# Altium schematic sheet PowerSchem.SchDoc(PowerSchem) (continued)
## Library data of the parts (continued)
R56 = RES: 100mW Thick Film Resistors 75V ±100ppm/℃ ±1% 4.99kΩ 0603 Chip Resistor - Surface Mount ROHS; Manufacturer: Yageo Group; Manufacturer Part Number: RC0603FR-074K99L; Temperature Coefficient: 100ppm/°C; Voltage Rating (DC): 50V; Case Code (Imperial): 0603; Case/Package: 0603; Category: Chip SMD Resistors; China RoHS: Non-Compliant; Component Kind: Standard; ComponentLink1Description: https://datasheet.octopart.com:443/RC0603FR-074K99L-Yageo-datasheet-175887523.pdf?src-supplier=IHS; ComponentLink1URL: https://datasheet.octopart.com:443/RC0603FR-074K99L-Yageo-datasheet-175887523.pdf?src-supplier=IHS; ComponentLink2Description: https://datasheet.octopart.com:443/RC0603FR-074K99L-Yageo-datasheet-8328172.pdf?src-supplier=Newark; ComponentLink2URL: https://datasheet.octopart.com:443/RC0603FR-074K99L-Yageo-datasheet-8328172.pdf?src-supplier=Newark; ComponentLink3Description: https://datasheet.octopart.com:443/RC0603FR-074K99L-Yageo-datasheet-34211833.pdf?src-supplier=Newark; ComponentLink3URL: https://datasheet.octopart.com:443/RC0603FR-074K99L-Yageo-datasheet-34211833.pdf?src-supplier=Newark; ComponentLink4Description: https://datasheet.octopart.com:443/RC0603FR-074K99L-Yageo-datasheet-103092701.pdf?src-supplier=Upverter; ComponentLink4URL: https://datasheet.octopart.com:443/RC0603FR-074K99L-Yageo-datasheet-103092701.pdf?src-supplier=Upverter; ComponentLink5Description: https://datasheet.octopart.com:443/RC0603FR-074K99L-Yageo-datasheet-79472498.pdf?src-supplier=Upverter; ComponentLink5URL: https://datasheet.octopart.com:443/RC0603FR-074K99L-Yageo-datasheet-79472498.pdf?src-supplier=Upverter; ComponentLink6Description: https://datasheet.octopart.com:443/RC0603FR-074K99L-Yageo-datasheet-62067350.pdf?src-supplier=Farnell; ComponentLink6URL: https://datasheet.octopart.com:443/RC0603FR-074K99L-Yageo-datasheet-62067350.pdf?src-supplier=Farnell; ComponentLink7Description: https://datasheet.octopart.com:443/RC0603FR-074K99L-Yageo-Group-datasheet-176638170.pdf?src-supplier=Future+Electronics; ComponentLink7URL: https://datasheet.octopart.com:443/RC0603FR-074K99L-Yageo-Group-datasheet-176638170.pdf?src-supplier=Future+Electronics; ComponentLink8Description: https://datasheet.octopart.com:443/RC0603FR-074K99L-Yageo-datasheet-164759695.pdf?src-supplier=Future+Electronics; ComponentLink8URL: https://datasheet.octopart.com:443/RC0603FR-074K99L-Yageo-datasheet-164759695.pdf?src-supplier=Future+Electronics; ComponentLink9Description: https://datasheet.octopart.com:443/RC0603FR-074K99L-Yageo-datasheet-137700087.pdf?src-supplier=Future+Electronics; ComponentLink9URL: https://datasheet.octopart.com:443/RC0603FR-074K99L-Yageo-datasheet-137700087.pdf?src-supplier=Future+Electronics; ComponentLink10Description: https://datasheet.octopart.com:443/RC0603FR-074K99L-Yageo-datasheet-127823281.pdf?src-supplier=Future+Electronics; ComponentLink10URL: https://datasheet.octopart.com:443/RC0603FR-074K99L-Yageo-datasheet-127823281.pdf?src-supplier=Future+Electronics; ComponentLink11Description: https://datasheet.octopart.com:443/RC0603FR074K99L-Yageo-datasheet-27584510.pdf?src-supplier=Future+Electronics; ComponentLink11URL: https://datasheet.octopart.com:443/RC0603FR074K99L-Yageo-datasheet-27584510.pdf?src-supplier=Future+Electronics; ComponentLink12Description: https://datasheet.octopart.com:443/RC0603FR-074K99L-Yageo-datasheet-15599030.pdf?src-supplier=Future+Electronics; ComponentLink12URL: https://datasheet.octopart.com:443/RC0603FR-074K99L-Yageo-datasheet-15599030.pdf?src-supplier=Future+Electronics; ComponentLink13Description: https://datasheet.octopart.com:443/RC0603FR-074K99L-Yageo-datasheet-12596169.pdf?src-supplier=Future+Electronics; ComponentLink13URL: https://datasheet.octopart.com:443/RC0603FR-074K99L-Yageo-datasheet-12596169.pdf?src-supplier=Future+Electronics; ComponentLink14Description: https://datasheet.octopart.com:443/RC0603FR-074K99L-Yageo-datasheet-503470.pdf?src-supplier=Future+Electronics; ComponentLink14URL: https://datasheet.octopart.com:443/RC0603FR-074K99L-Yageo-datasheet-503470.pdf?src-supplier=Future+Electronics; ComponentLink15Description: https://datasheet.octopart.com/RC0603FR-074K99L-Yageo-datasheet-73766026.pdf; ComponentLink15URL: https://datasheet.octopart.com/RC0603FR-074K99L-Yageo-datasheet-73766026.pdf; ComponentLink16Description: https://datasheet.octopart.com:443/RC0603FR-074K99L-Yageo-datasheet-118562.pdf?src-supplier=DigiKey; ComponentLink16URL: https://datasheet.octopart.com:443/RC0603FR-074K99L-Yageo-datasheet-118562.pdf?src-supplier=DigiKey; ComponentLink17Description: http://sigma.octopart.com:80/conflict_mineral_statement/Yageo-company-3.pdf?src-supplier=Compliance+Statements; ComponentLink17URL: http://sigma.octopart.com:80/conflict_mineral_statement/Yageo-company-3.pdf?src-supplier=Compliance+Statements; ComponentLink18Description: http://sigma.octopart.com:80/rohs_statement/Yageo-company-2.pdf?src-supplier=Compliance+Statements; ComponentLink18URL: http://sigma.octopart.com:80/rohs_statement/Yageo-company-2.pdf?src-supplier=Compliance+Statements; Composition: Thick Film; Contact Plating: Tin; Country of Origin: Mainland China, Taiwan; Depth: 800µm; Export Control Classification Number (ECCN) Code: EAR99; Features: Moisture Resistant; Harmonized Tariff Schedule (HTS) Code: 8533.21.00.30; Height: 450µm; Introduction Date: 2004-09-22; Lead Free: Lead Free; Length: 1.6mm; Library Name: Standard.SchLib; Lifecycle Status: Production; Max Operating Temperature: 155°C; Max Power Dissipation: 100mW; Military Standard: Not; Min Operating Temperature: -55°C; Mounting Technology: Surface Mount; Number of Terminals: 2; Number of Terminations: 2; Packaging: Tape & Reel; Pins: 2; Power Rating: 100mW; Pricing: 5000=0.00137, 10000=0.00137, 20000=0.00136, 40000=0.00135, 80000=0.00134 (USD); Radiation Hardening: No; REACH SVHC: Yes; RoHS: Compliant; Schedule B: 8533210045, 8533210045|8533210045|8533210045|8533210045|8533210045|8533210045|8533210045|8533210045|8533210045|8533210045|8533210045|8533210045|8533210045|8533210045|8533210045|8533210045|8533210045|8533210045|8533210045|8533210045|8533210045|8533210045|8533210045|85; Stock: 665000; Supplier 1: Avnet; Supplier Part Number 1: RC0603FR-074K99L; Termination: SMD/SMT; Tolerance: 1%; Value: 4k99; Voltage Rating: 75V; Weight: 2.012816mg; Width: 800µm; Working Voltage: 75V
R57 = RES: Res Thick Film 0603 14.7K Ohm 1% 0.1W(1/10W) ±100ppm/C Pad SMD T/R Automotive AEC-Q200; Manufacturer: Stackpole Electronics; Manufacturer Part Number: RMCF0603FT14K7; Max Power Dissipation: 100mW; Temperature Coefficient: 100ppm/°C; Voltage Rating: 75V; Case Code (Imperial): 0603; Case Code (Metric): 1608; Case/Package: 0603; Category: Chip SMD Resistors; China RoHS: Non-Compliant; Component Kind: Standard; ComponentLink1Description: https://datasheet.octopart.com:443/RMCF0603FT14K7-Stackpole-Electronics-datasheet-182148065.pdf?src-supplier=IHS; ComponentLink1URL: https://datasheet.octopart.com:443/RMCF0603FT14K7-Stackpole-Electronics-datasheet-182148065.pdf?src-supplier=IHS; ComponentLink2Description: https://datasheet.octopart.com:443/RMCF0603FT14K7-Stackpole-Electronics-datasheet-28504820.pdf?src-supplier=Upverter; ComponentLink2URL: https://datasheet.octopart.com:443/RMCF0603FT14K7-Stackpole-Electronics-datasheet-28504820.pdf?src-supplier=Upverter; ComponentLink3Description: https://datasheet.octopart.com:443/RMCF0603FT14K7-Stackpole-Electronics-datasheet-141193804.pdf?src-supplier=Master+Electronics; ComponentLink3URL: https://datasheet.octopart.com:443/RMCF0603FT14K7-Stackpole-Electronics-datasheet-141193804.pdf?src-supplier=Master+Electronics; ComponentLink4Description: https://datasheet.octopart.com:443/RMCF0603FT14K7-Stackpole-Electronics-datasheet-189104163.pdf?src-supplier=Master+Electronics; ComponentLink4URL: https://datasheet.octopart.com:443/RMCF0603FT14K7-Stackpole-Electronics-datasheet-189104163.pdf?src-supplier=Master+Electronics; ComponentLink5Description: https://datasheet.octopart.com:443/RMCF0603FT14K7-Stackpole-Electronics-datasheet-116606903.pdf?src-supplier=Master+Electronics; ComponentLink5URL: https://datasheet.octopart.com:443/RMCF0603FT14K7-Stackpole-Electronics-datasheet-116606903.pdf?src-supplier=Master+Electronics; ComponentLink6Description: https://datasheet.octopart.com:443/RMCF0603FT14K7-Stackpole-Electronics-datasheet-62091608.pdf?src-supplier=Stackpole+Electronics; ComponentLink6URL: https://datasheet.octopart.com:443/RMCF0603FT14K7-Stackpole-Electronics-datasheet-62091608.pdf?src-supplier=Stackpole+Electronics; ComponentLink7Description: https://datasheet.octopart.com:443/RMCF0603FT14K7-Stackpole-Electronics-datasheet-12534581.pdf?src-supplier=Stackpole+Electronics; ComponentLink7URL: https://datasheet.octopart.com:443/RMCF0603FT14K7-Stackpole-Electronics-datasheet-12534581.pdf?src-supplier=Stackpole+Electronics; ComponentLink8Description: https://datasheet.octopart.com:443/RMCF0603FT14K7-Stackpole-Electronics-datasheet-10788263.pdf?src-supplier=Stackpole+Electronics; ComponentLink8URL: https://datasheet.octopart.com:443/RMCF0603FT14K7-Stackpole-Electronics-datasheet-10788263.pdf?src-supplier=Stackpole+Electronics; ComponentLink9Description: http://sigma.octopart.com:80/15940719/materials_sheet/Stackpole-Electronics-RMCF0603FT14K7.pdf?src-supplier=Compliance+Statements; ComponentLink9URL: http://sigma.octopart.com:80/15940719/materials_sheet/Stackpole-Electronics-RMCF0603FT14K7.pdf?src-supplier=Compliance+Statements; ComponentLink10Description: http://sigma.octopart.com:80/141158212/rohs_statement/Stackpole-Electronics-RMCF0603FT14K7.pdf?src-supplier=Compliance+Statements; ComponentLink10URL: http://sigma.octopart.com:80/141158212/rohs_statement/Stackpole-Electronics-RMCF0603FT14K7.pdf?src-supplier=Compliance+Statements; ComponentLink11Description: http://sigma.octopart.com:80/141158211/reach_statement/Stackpole-Electronics-RMCF0603FT14K7.pdf?src-supplier=Compliance+Statements; ComponentLink11URL: http://sigma.octopart.com:80/141158211/reach_statement/Stackpole-Electronics-RMCF0603FT14K7.pdf?src-supplier=Compliance+Statements; Composition: Thick Film; Contact Plating: Tin; Country of Origin: Japan, Mainland China, Taiwan; Export Control Classification Number (ECCN) Code: EAR99; Features: Automotive AEC-Q200; Harmonized Tariff Schedule (HTS) Code: 8533.21.00.30; Height: 450µm; Introduction Date: 2011-01-07; Lead Free: Lead Free; Length: 1.55mm; Library Name: Standard.SchLib; Lifecycle Status: Production; Max Operating Temperature: 155°C; Min Operating Temperature: -55°C; Mounting Technology: Surface Mount; Number of Terminals: 2; Number of Terminations: 2; Packaging: Cut Tape (CT); Power Rating: 100mW; Pricing: 5000=0.0019, 10000=0.00188, 20000=0.00185, 40000=0.00182, 80000=0.00179 (USD); Radiation Hardening: No; Ratings: AEC-Q200; REACH SVHC: Yes; RoHS: Compliant; Schedule B: 8533210045, 8533210045|8533210045|8533210045|8533210045|8533210045; Stock: 170000; Supplier 1: Avnet; Supplier Part Number 1: RMCF0603FT14K7; Tolerance: 1%; Value: 14k7; Width: 800µm; Working Voltage: 75V
R59 = RES: 100mW Thick Film Resistors 75V ±100ppm/℃ ±1% 113kΩ 0603 Chip Resistor - Surface Mount ROHS; Manufacturer: Yageo Group; Manufacturer Part Number: RC0603FR-07113KL; Temperature Coefficient: 100ppm/°C; Voltage Rating (DC): 50V; Case Code (Imperial): 0603; Case/Package: 0603; Category: Chip SMD Resistors; China RoHS: Non-Compliant; Component Kind: Standard; ComponentLink1Description: https://datasheet.octopart.com:443/CPF0402B200KE1-TE-Connectivity-datasheet-168801553.pdf?src-supplier=Farnell; ComponentLink1URL: https://datasheet.octopart.com:443/CPF0402B200KE1-TE-Connectivity-datasheet-168801553.pdf?src-supplier=Farnell; ComponentLink2Description: https://datasheet.octopart.com:443/CPF0402B200KE1-TE-Connectivity-datasheet-66077623.pdf?src-supplier=Farnell; ComponentLink2URL: https://datasheet.octopart.com:443/CPF0402B200KE1-TE-Connectivity-datasheet-66077623.pdf?src-supplier=Farnell; ComponentLink3Description: https://datasheet.octopart.com:443/CPF0402B200KE1-TE-Connectivity-datasheet-16487054.pdf?src-supplier=Farnell; ComponentLink3URL: https://datasheet.octopart.com:443/CPF0402B200KE1-TE-Connectivity-datasheet-16487054.pdf?src-supplier=Farnell; ComponentLink4Description: https://datasheet.octopart.com:443/CPF0402B200KE1-TE-Connectivity-datasheet-136771900.pdf?src-supplier=Electro+Sonic; ComponentLink4URL: https://datasheet.octopart.com:443/CPF0402B200KE1-TE-Connectivity-datasheet-136771900.pdf?src-supplier=Electro+Sonic; ComponentLink5Description: https://datasheet.octopart.com:443/CPF0402B200KE1-Tyco-Electronics-datasheet-11120060.pdf?src-supplier=Electro+Sonic; ComponentLink5URL: https://datasheet.octopart.com:443/CPF0402B200KE1-Tyco-Electronics-datasheet-11120060.pdf?src-supplier=Electro+Sonic; ComponentLink6Description: https://datasheet.octopart.com:443/CPF0402B200KE1-TE-Connectivity-datasheet-78339038.pdf?src-supplier=Master+Electronics; ComponentLink6URL: https://datasheet.octopart.com:443/CPF0402B200KE1-TE-Connectivity-datasheet-78339038.pdf?src-supplier=Master+Electronics; ComponentLink7Description: https://datasheet.octopart.com:443/CPF0402B200KE1-TE-Connectivity-datasheet-62056503.pdf?src-supplier=Master+Electronics; ComponentLink7URL: https://datasheet.octopart.com:443/CPF0402B200KE1-TE-Connectivity-datasheet-62056503.pdf?src-supplier=Master+Electronics; ComponentLink8Description: https://datasheet.octopart.com:443/CPF0402B200KE1-TE-Connectivity-datasheet-11120284.pdf?src-supplier=Master+Electronics; ComponentLink8URL: https://datasheet.octopart.com:443/CPF0402B200KE1-TE-Connectivity-datasheet-11120284.pdf?src-supplier=Master+Electronics; ComponentLink9Description: https://datasheet.octopart.com:443/3-1879216-5-TE-Connectivity---AMP-datasheet-187588164.pdf?src-supplier=Master+Electronics; ComponentLink9URL: https://datasheet.octopart.com:443/3-1879216-5-TE-Connectivity---AMP-datasheet-187588164.pdf?src-supplier=Master+Electronics; ComponentLink10Description: https://datasheet.octopart.com:443/CPF0402B200KE1-TE-Connectivity-datasheet-17911634.pdf?src-supplier=Master+Electronics; ComponentLink10URL: https://datasheet.octopart.com:443/CPF0402B200KE1-TE-Connectivity-datasheet-17911634.pdf?src-supplier=Master+Electronics; ComponentLink11Description: https://datasheet.octopart.com:443/CPF0402B200KE1-Neohm-datasheet-140860410.pdf?src-supplier=Master+Electronics; ComponentLink11URL: https://datasheet.octopart.com:443/CPF0402B200KE1-Neohm-datasheet-140860410.pdf?src-supplier=Master+Electronics; ComponentLink12Description: https://datasheet.octopart.com:443/CPF0402B200KE1-TE-Connectivity-datasheet-11120073.pdf?src-supplier=TE+Connectivity; ComponentLink12URL: https://datasheet.octopart.com:443/CPF0402B200KE1-TE-Connectivity-datasheet-11120073.pdf?src-supplier=TE+Connectivity; ComponentLink13Description: https://sigma.octopart.com:443/14690934/technical_drawing/TE-Connectivity-CPF0402B200KE1.pdf?src-supplier=TE+Connectivity; ComponentLink13URL: https://sigma.octopart.com:443/14690934/technical_drawing/TE-Connectivity-CPF0402B200KE1.pdf?src-supplier=TE+Connectivity; ComponentLink14Description: https://datasheet.octopart.com:443/CPF0402B200KE1-TE-Connectivity---Neohm-datasheet-165693140.pdf?src-supplier=element14+APAC; ComponentLink14URL: https://datasheet.octopart.com:443/CPF0402B200KE1-TE-Connectivity---Neohm-datasheet-165693140.pdf?src-supplier=element14+APAC; ComponentLink15Description: https://datasheet.octopart.com:443/3-1879216-5-TE-Connectivity-datasheet-158231717.pdf?src-supplier=TME; ComponentLink15URL: https://datasheet.octopart.com:443/3-1879216-5-TE-Connectivity-datasheet-158231717.pdf?src-supplier=TME; ComponentLink16Description: https://datasheet.octopart.com:443/3-1879216-5-TE-Connectivity---AMP-datasheet-21631865.pdf?src-supplier=Future+Electronics; ComponentLink16URL: https://datasheet.octopart.com:443/3-1879216-5-TE-Connectivity---AMP-datasheet-21631865.pdf?src-supplier=Future+Electronics; ComponentLink17Description: http://sigma.octopart.com:80/14831708/rohs_certificate/TE-Connectivity-CPF0402B200KE1.pdf?src-supplier=Compliance+Statements; ComponentLink17URL: http://sigma.octopart.com:80/14831708/rohs_certificate/TE-Connectivity-CPF0402B200KE1.pdf?src-supplier=Compliance+Statements; ComponentLink18Description: http://sigma.octopart.com:80/47028450/materials_sheet/TE-Connectivity-CPF0402B200KE1.pdf?src-supplier=Compliance+Statements; ComponentLink18URL: http://sigma.octopart.com:80/47028450/materials_sheet/TE-Connectivity-CPF0402B200KE1.pdf?src-supplier=Compliance+Statements; ComponentLink19Description: https://datasheet.octopart.com:443/RC0603FR-07113KL-Yageo-datasheet-175887523.pdf?src-supplier=Newark; ComponentLink19URL: https://datasheet.octopart.com:443/RC0603FR-07113KL-Yageo-datasheet-175887523.pdf?src-supplier=Newark; ComponentLink20Description: https://datasheet.octopart.com:443/RC0603FR-07113KL-Yageo-datasheet-103092701.pdf?src-supplier=Upverter; ComponentLink20URL: https://datasheet.octopart.com:443/RC0603FR-07113KL-Yageo-datasheet-103092701.pdf?src-supplier=Upverter; ComponentLink21Description: https://datasheet.octopart.com:443/RC0603FR-07113KL-Yageo-Group-datasheet-189192902.pdf?src-supplier=TME; ComponentLink21URL: https://datasheet.octopart.com:443/RC0603FR-07113KL-Yageo-Group-datasheet-189192902.pdf?src-supplier=TME; ComponentLink22Description: https://datasheet.octopart.com:443/RC0603FR-07113KL-Yageo-Group-datasheet-176638170.pdf?src-supplier=Future+Electronics; ComponentLink22URL: https://datasheet.octopart.com:443/RC0603FR-07113KL-Yageo-Group-datasheet-176638170.pdf?src-supplier=Future+Electronics; ComponentLink23Description: https://datasheet.octopart.com:443/RC0603FR-07113KL-Yageo-datasheet-164759695.pdf?src-supplier=Future+Electronics; ComponentLink23URL: https://datasheet.octopart.com:443/RC0603FR-07113KL-Yageo-datasheet-164759695.pdf?src-supplier=Future+Electronics; ComponentLink24Description: https://datasheet.octopart.com:443/RC0603FR-07113KL-Yageo-datasheet-137700087.pdf?src-supplier=Future+Electronics; ComponentLink24URL: https://datasheet.octopart.com:443/RC0603FR-07113KL-Yageo-datasheet-137700087.pdf?src-supplier=Future+Electronics; ComponentLink25Description: https://datasheet.octopart.com:443/RC0603FR-07113KL-Yageo-datasheet-15599030.pdf?src-supplier=Future+Electronics; ComponentLink25URL: https://datasheet.octopart.com:443/RC0603FR-07113KL-Yageo-datasheet-15599030.pdf?src-supplier=Future+Electronics; ComponentLink26Description: https://datasheet.octopart.com:443/RC0603FR-07113KL-Yageo-datasheet-12596169.pdf?src-supplier=Future+Electronics; ComponentLink26URL: https://datasheet.octopart.com:443/RC0603FR-07113KL-Yageo-datasheet-12596169.pdf?src-supplier=Future+Electronics; ComponentLink27Description: https://datasheet.octopart.com:443/RC0603FR-07113KL-Yageo-datasheet-8328172.pdf?src-supplier=Future+Electronics; ComponentLink27URL: https://datasheet.octopart.com:443/RC0603FR-07113KL-Yageo-datasheet-8328172.pdf?src-supplier=Future+Electronics; ComponentLink28Description: https://datasheet.octopart.com/RC0603FR-07113KL-Yageo-datasheet-73766026.pdf; ComponentLink28URL: https://datasheet.octopart.com/RC0603FR-07113KL-Yageo-datasheet-73766026.pdf; ComponentLink29Description: https://datasheet.octopart.com:443/RC0603FR-07113KL-Yageo-datasheet-118562.pdf?src-supplier=DigiKey; ComponentLink29URL: https://datasheet.octopart.com:443/RC0603FR-07113KL-Yageo-datasheet-118562.pdf?src-supplier=DigiKey; ComponentLink30Description: http://sigma.octopart.com:80/conflict_mineral_statement/Yageo-company-3.pdf?src-supplier=Compliance+Statements; ComponentLink30URL: http://sigma.octopart.com:80/conflict_mineral_statement/Yageo-company-3.pdf?src-supplier=Compliance+Statements; ComponentLink31Description: http://sigma.octopart.com:80/rohs_statement/Yageo-company-2.pdf?src-supplier=Compliance+Statements; ComponentLink31URL: http://sigma.octopart.com:80/rohs_statement/Yageo-company-2.pdf?src-supplier=Compliance+Statements; Composition: Thick Film; Contact Plating: Lead, Tin; Depth: 800µm; Height: 450µm; Lead Free: Lead Free; Length: 1.6mm; Library Name: Passives.SchLib; Lifecycle Status: Production; Max Operating Temperature: 155°C; Military Standard: Not; Min Operating Temperature: -55°C; Mounting Technology: Surface Mount; Number of Terminals: 2; Packaging: Tape & Reel; Power Rating: 100mW; Pricing: 5000=0.00137, 10000=0.00137, 20000=0.00136, 40000=0.00135, 80000=0.00134 (USD); Radiation Hardening: No; REACH SVHC: Yes; RoHS: Compliant; Schedule B: 8533210045, 8533210045|8533210045, 8533210045|8533210045|8533210045, 8533210045|8533210045|8533210045|8533210045; Stock: 15000; Supplier 1: Avnet; Supplier Part Number 1: RC0603FR-07113KL; Termination: SMD/SMT; Tolerance: 1%; Value: 113k; Voltage Rating: 75V; Width: 800µm; Working Voltage: 75V
R60 R61 = RES: 100mW Thick Film Resistors ±100ppm/℃ ±1% 10kΩ 0603 Chip Resistor - Surface Mount ROHS; Manufacturer: Yageo Group; Manufacturer Part Number: RC0603FR-0710KL; Temperature Coefficient: 100ppm/°C; Voltage Rating: 75V; Voltage Rating (DC): 50V; Case Code (Imperial): 0603; Case Code (Metric): 0603; Case/Package: 0603; Category: Chip SMD Resistors; China RoHS: Non-Compliant; Component Kind: Standard; ComponentLink1Description: https://datasheet.octopart.com:443/RC0603FR-0710KL-Yageo-datasheet-175887523.pdf?src-supplier=IHS; ComponentLink1URL: https://datasheet.octopart.com:443/RC0603FR-0710KL-Yageo-datasheet-175887523.pdf?src-supplier=IHS; ComponentLink2Description: https://datasheet.octopart.com:443/RC0603FR-0710KL-Yageo-datasheet-103092701.pdf?src-supplier=Upverter; ComponentLink2URL: https://datasheet.octopart.com:443/RC0603FR-0710KL-Yageo-datasheet-103092701.pdf?src-supplier=Upverter; ComponentLink3Description: https://datasheet.octopart.com:443/RC0603FR-0710KL-Yageo-datasheet-98239111.pdf?src-supplier=Upverter; ComponentLink3URL: https://datasheet.octopart.com:443/RC0603FR-0710KL-Yageo-datasheet-98239111.pdf?src-supplier=Upverter; ComponentLink4Description: https://datasheet.octopart.com:443/RC0603FR-0710KL-Yageo-datasheet-141265859.pdf?src-supplier=Upverter; ComponentLink4URL: https://datasheet.octopart.com:443/RC0603FR-0710KL-Yageo-datasheet-141265859.pdf?src-supplier=Upverter; ComponentLink5Description: https://sigma.octopart.com:443/138820526/technical_drawing/Yageo-RC0603FR-0710KL.pdf?src-supplier=Upverter; ComponentLink5URL: https://sigma.octopart.com:443/138820526/technical_drawing/Yageo-RC0603FR-0710KL.pdf?src-supplier=Upverter; ComponentLink6Description: https://datasheet.octopart.com:443/RC0603FR-0710KL-Yageo-datasheet-8328172.pdf?src-supplier=Farnell; ComponentLink6URL: https://datasheet.octopart.com:443/RC0603FR-0710KL-Yageo-datasheet-8328172.pdf?src-supplier=Farnell; ComponentLink7Description: https://datasheet.octopart.com:443/RC0603FR-0710KL-Yageo-datasheet-13691069.pdf?src-supplier=Farnell; ComponentLink7URL: https://datasheet.octopart.com:443/RC0603FR-0710KL-Yageo-datasheet-13691069.pdf?src-supplier=Farnell; ComponentLink8Description: https://datasheet.octopart.com:443/RC0603FR-0710KL-Yageo-datasheet-62067350.pdf?src-supplier=element14+APAC; ComponentLink8URL: https://datasheet.octopart.com:443/RC0603FR-0710KL-Yageo-datasheet-62067350.pdf?src-supplier=element14+APAC; ComponentLink9Description: https://datasheet.octopart.com:443/RC0603FR-0710KL-Yageo-datasheet-17842380.pdf?src-supplier=element14+APAC; ComponentLink9URL: https://datasheet.octopart.com:443/RC0603FR-0710KL-Yageo-datasheet-17842380.pdf?src-supplier=element14+APAC; ComponentLink10Description: https://datasheet.octopart.com:443/RC0603FR-0710KL-Yageo-datasheet-15599030.pdf?src-supplier=Future+Electronics; ComponentLink10URL: https://datasheet.octopart.com:443/RC0603FR-0710KL-Yageo-datasheet-15599030.pdf?src-supplier=Future+Electronics; ComponentLink11Description: https://datasheet.octopart.com:443/RC0603FR-0710KL-Yageo-Group-datasheet-176638170.pdf?src-supplier=Future+Electronics; ComponentLink11URL: https://datasheet.octopart.com:443/RC0603FR-0710KL-Yageo-Group-datasheet-176638170.pdf?src-supplier=Future+Electronics; ComponentLink12Description: https://datasheet.octopart.com:443/RC0603FR-0710KL-Yageo-datasheet-164759695.pdf?src-supplier=Future+Electronics; ComponentLink12URL: https://datasheet.octopart.com:443/RC0603FR-0710KL-Yageo-datasheet-164759695.pdf?src-supplier=Future+Electronics; ComponentLink13Description: https://datasheet.octopart.com:443/RC0603FR-0710KL-Yageo-datasheet-127823281.pdf?src-supplier=Future+Electronics; ComponentLink13URL: https://datasheet.octopart.com:443/RC0603FR-0710KL-Yageo-datasheet-127823281.pdf?src-supplier=Future+Electronics; ComponentLink14Description: https://datasheet.octopart.com:443/RC0603FR-0710KL-Yageo-datasheet-137700087.pdf?src-supplier=Future+Electronics; ComponentLink14URL: https://datasheet.octopart.com:443/RC0603FR-0710KL-Yageo-datasheet-137700087.pdf?src-supplier=Future+Electronics; ComponentLink15Description: https://datasheet.octopart.com:443/RC0603FR-0710KL-Yageo-datasheet-27584510.pdf?src-supplier=Future+Electronics; ComponentLink15URL: https://datasheet.octopart.com:443/RC0603FR-0710KL-Yageo-datasheet-27584510.pdf?src-supplier=Future+Electronics; ComponentLink16Description: https://datasheet.octopart.com:443/RC0603FR-0710KL-Yageo-datasheet-8324193.pdf?src-supplier=Future+Electronics; ComponentLink16URL: https://datasheet.octopart.com:443/RC0603FR-0710KL-Yageo-datasheet-8324193.pdf?src-supplier=Future+Electronics; ComponentLink17Description: https://datasheet.octopart.com:443/RC0603FR-0710KL-Yageo-datasheet-12596169.pdf?src-supplier=Newark; ComponentLink17URL: https://datasheet.octopart.com:443/RC0603FR-0710KL-Yageo-datasheet-12596169.pdf?src-supplier=Newark; ComponentLink18Description: https://datasheet.octopart.com:443/RC0603FR-0710KL-Yageo-datasheet-503470.pdf?src-supplier=Newark; ComponentLink18URL: https://datasheet.octopart.com:443/RC0603FR-0710KL-Yageo-datasheet-503470.pdf?src-supplier=Newark; ComponentLink19Description: https://datasheet.octopart.com:443/RC0603FR-0710KL-Yageo-datasheet-34211833.pdf?src-supplier=Newark; ComponentLink19URL: https://datasheet.octopart.com:443/RC0603FR-0710KL-Yageo-datasheet-34211833.pdf?src-supplier=Newark; ComponentLink20Description: https://datasheet.octopart.com:443/RC0603FR-0710KL-Yageo-datasheet-79472498.pdf?src-supplier=Megastar; ComponentLink20URL: https://datasheet.octopart.com:443/RC0603FR-0710KL-Yageo-datasheet-79472498.pdf?src-supplier=Megastar; ComponentLink21Description: https://datasheet.octopart.com/RC0603FR-0710KL-Yageo-datasheet-73766026.pdf; ComponentLink21URL: https://datasheet.octopart.com/RC0603FR-0710KL-Yageo-datasheet-73766026.pdf; ComponentLink22Description: https://datasheet.octopart.com:443/RC0603FR-0710KL-Yageo-datasheet-118562.pdf?src-supplier=DigiKey; ComponentLink22URL: https://datasheet.octopart.com:443/RC0603FR-0710KL-Yageo-datasheet-118562.pdf?src-supplier=DigiKey; ComponentLink23Description: http://sigma.octopart.com:80/conflict_mineral_statement/Yageo-company-3.pdf?src-supplier=Compliance+Statements; ComponentLink23URL: http://sigma.octopart.com:80/conflict_mineral_statement/Yageo-company-3.pdf?src-supplier=Compliance+Statements; ComponentLink24Description: http://sigma.octopart.com:80/rohs_statement/Yageo-company-2.pdf?src-supplier=Compliance+Statements; ComponentLink24URL: http://sigma.octopart.com:80/rohs_statement/Yageo-company-2.pdf?src-supplier=Compliance+Statements; Composition: Thick Film; Contact Plating: Tin; Depth: 800µm; Height: 450µm; Lead Free: Lead Free; Lead Pitch: 300µm; Length: 1.6mm; Library Name: Passives.SchLib; Lifecycle Status: Production; Max Operating Temperature: 155°C; Military Standard: Not; Min Operating Temperature: -55°C; Mounting Technology: Surface Mount; Number of Terminals: 2; Packaging: Tape & Reel; Pins: 2; Power Rating: 100mW; Pricing: 5000=0.00136, 10000=0.00132, 20000=0.00129, 40000=0.00126, 80000=0.00123 (USD); Radiation Hardening: No; REACH SVHC: Yes; RoHS: Compliant; Schedule B: 8533210045, 8533210045|8533210045|8533210045|8533210045|8533210045|8533210045|8533210045|8533210045|8533210045|8533210045|8533210045|8533210045|8533210045|8533210045|8533210045|8533210045|8533210045|8533210045|8533210045|8533210045|8533210045|8533210045|8533210045|85; Stock: 2520000; Supplier 1: Avnet; Supplier Part Number 1: RC0603FR-0710KL; Termination: SMD/SMT; Tolerance: 1%; Value: 10k; Weight: 2.012816mg; Width: 800µm; Working Voltage: 75V
R62 = RES: Res Thick Film 0603 237K Ohm 1% 0.1W(1/10W) ±100ppm/C Pad SMD Automotive T/R; Manufacturer: Yageo Group; Manufacturer Part Number: AC0603FR-07237KL; Max Power Dissipation: 100mW; Temperature Coefficient: 100ppm/°C; Voltage Rating: 75V; Case/Package: 0603; Category: Chip SMD Resistors; China RoHS: Non-Compliant; Component Kind: Standard; ComponentLink1Description: https://datasheet.octopart.com:443/AC0603FR-07237KL-Yageo-datasheet-182189377.pdf?src-supplier=IHS; ComponentLink1URL: https://datasheet.octopart.com:443/AC0603FR-07237KL-Yageo-datasheet-182189377.pdf?src-supplier=IHS; ComponentLink2Description: https://datasheet.octopart.com:443/AC0603FR-07237KL-Yageo-datasheet-86786639.pdf?src-supplier=Upverter; ComponentLink2URL: https://datasheet.octopart.com:443/AC0603FR-07237KL-Yageo-datasheet-86786639.pdf?src-supplier=Upverter; ComponentLink3Description: https://datasheet.octopart.com:443/AC0603FR-07237KL-Yageo-datasheet-178509465.pdf?src-supplier=Farnell; ComponentLink3URL: https://datasheet.octopart.com:443/AC0603FR-07237KL-Yageo-datasheet-178509465.pdf?src-supplier=Farnell; ComponentLink4Description: https://datasheet.octopart.com/AC0603FR-07237KL-Yageo-datasheet-12512238.pdf; ComponentLink4URL: https://datasheet.octopart.com/AC0603FR-07237KL-Yageo-datasheet-12512238.pdf; ComponentLink5Description: http://sigma.octopart.com:80/conflict_mineral_statement/Yageo-company-3.pdf?src-supplier=Compliance+Statements; ComponentLink5URL: http://sigma.octopart.com:80/conflict_mineral_statement/Yageo-company-3.pdf?src-supplier=Compliance+Statements; ComponentLink6Description: http://sigma.octopart.com:80/rohs_statement/Yageo-company-2.pdf?src-supplier=Compliance+Statements; ComponentLink6URL: http://sigma.octopart.com:80/rohs_statement/Yageo-company-2.pdf?src-supplier=Compliance+Statements; Composition: Thick Film; Features: Automotive AEC-Q200, Moisture Resistant; Height: 450µm; Length: 1.6mm; Library Name: Passives.SchLib; Lifecycle Status: Production; Max Operating Temperature: 155°C; Min Operating Temperature: -55°C; Mounting Technology: Surface Mount; Number of Terminals: 2; Number of Terminations: 2; Packaging: Tape & Reel; Power Rating: 100mW; Pricing: 1=0.103, 25=0.008 (USD); Ratings: AEC-Q200; REACH SVHC: Yes; RoHS: Compliant; Stock: 9242; Supplier 1: Avnet; Supplier Part Number 1: 59AK3101; Tolerance: 1%; Value: 237k; Width: 800µm; Working Voltage: 75V
R63 = RES: Fixed Resistor, Thin Film, 0.1W, 357000ohm, 75V, 0.1% +/-Tol, 25ppm/Cel, Surface Mount, 0603; Manufacturer: Yageo Group; Manufacturer Part Number: RT0603BRD07357KL; Max Power Dissipation: 100mW; Temperature Coefficient: 25ppm/°C; Case Code (Imperial): 0603; Case Code (Metric): 1608; Case/Package: 0603; Category: Chip SMD Resistors; China RoHS: Non-Compliant; Component Kind: Standard; ComponentLink1Description: https://datasheet.octopart.com:443/RT0603BRD07357KL-Yageo-datasheet-176402239.pdf?src-supplier=Future+Electronics; ComponentLink1URL: https://datasheet.octopart.com:443/RT0603BRD07357KL-Yageo-datasheet-176402239.pdf?src-supplier=Future+Electronics; ComponentLink2Description: https://datasheet.octopart.com:443/RT0603BRD07357KL-Yageo-datasheet-169971040.pdf?src-supplier=Future+Electronics; ComponentLink2URL: https://datasheet.octopart.com:443/RT0603BRD07357KL-Yageo-datasheet-169971040.pdf?src-supplier=Future+Electronics; ComponentLink3Description: https://datasheet.octopart.com:443/RT0603BRD07357KL-Yageo-datasheet-96443642.pdf?src-supplier=Upverter; ComponentLink3URL: https://datasheet.octopart.com:443/RT0603BRD07357KL-Yageo-datasheet-96443642.pdf?src-supplier=Upverter; ComponentLink4Description: https://datasheet.octopart.com/RT0603BRD07357KL-Yageo-datasheet-86813807.pdf; ComponentLink4URL: https://datasheet.octopart.com/RT0603BRD07357KL-Yageo-datasheet-86813807.pdf; ComponentLink5Description: http://sigma.octopart.com:80/conflict_mineral_statement/Yageo-company-3.pdf?src-supplier=Compliance+Statements; ComponentLink5URL: http://sigma.octopart.com:80/conflict_mineral_statement/Yageo-company-3.pdf?src-supplier=Compliance+Statements; ComponentLink6Description: http://sigma.octopart.com:80/rohs_statement/Yageo-company-2.pdf?src-supplier=Compliance+Statements; ComponentLink6URL: http://sigma.octopart.com:80/rohs_statement/Yageo-company-2.pdf?src-supplier=Compliance+Statements; Composition: Thin Film; Features: Moisture Resistant; Height: 450µm; Length: 1.6mm; Library Name: Passives.SchLib; Lifecycle Status: Production; Max Operating Temperature: 155°C; Min Operating Temperature: -55°C; Mounting Technology: Surface Mount; Number of Terminals: 2; Number of Terminations: 2; Packaging: Tape & Reel; Power Rating: 100mW; Pricing: 1=0.1, 10=0.063, 25=0.0584, 50=0.055, 100=0.0519, 250=0.0482, 500=0.04558, 1000=0.04319 (USD); REACH SVHC: Yes; RoHS: Compliant; Stock: 5405; Supplier 1: DigiKey; Supplier Part Number 1: 13-RT0603BRD07357KLCT-ND; Termination: SMD/SMT; Tolerance: 0.1%; Value: 357k; Voltage Rating: 75V; Width: 800µm; Working Voltage: 75V
R64 = RES: 100mW Thick Film Resistors 75V ±100ppm/℃ ±1% 210kΩ 0603 Chip Resistor - Surface Mount ROHS; Manufacturer: Yageo Group; Manufacturer Part Number: RC0603FR-07210KL; Temperature Coefficient: 100ppm/°C; Voltage Rating (DC): 50V; Case Code (Imperial): 0603; Case/Package: 0603; Category: Chip SMD Resistors; China RoHS: Non-Compliant; Component Kind: Standard; ComponentLink1Description: https://datasheet.octopart.com:443/RC0603FR-07210KL-Yageo-datasheet-175887523.pdf?src-supplier=Newark; ComponentLink1URL: https://datasheet.octopart.com:443/RC0603FR-07210KL-Yageo-datasheet-175887523.pdf?src-supplier=Newark; ComponentLink2Description: https://datasheet.octopart.com:443/RC0603FR-07210KL-Yageo-datasheet-103092701.pdf?src-supplier=Upverter; ComponentLink2URL: https://datasheet.octopart.com:443/RC0603FR-07210KL-Yageo-datasheet-103092701.pdf?src-supplier=Upverter; ComponentLink3Description: https://datasheet.octopart.com:443/RC0603FR-07210KL-Yageo-Group-datasheet-189192902.pdf?src-supplier=TME; ComponentLink3URL: https://datasheet.octopart.com:443/RC0603FR-07210KL-Yageo-Group-datasheet-189192902.pdf?src-supplier=TME; ComponentLink4Description: https://datasheet.octopart.com:443/RC0603FR-07210KL-Yageo-Group-datasheet-176638170.pdf?src-supplier=Future+Electronics; ComponentLink4URL: https://datasheet.octopart.com:443/RC0603FR-07210KL-Yageo-Group-datasheet-176638170.pdf?src-supplier=Future+Electronics; ComponentLink5Description: https://datasheet.octopart.com:443/RC0603FR-07210KL-Yageo-datasheet-164759695.pdf?src-supplier=Future+Electronics; ComponentLink5URL: https://datasheet.octopart.com:443/RC0603FR-07210KL-Yageo-datasheet-164759695.pdf?src-supplier=Future+Electronics; ComponentLink6Description: https://datasheet.octopart.com:443/RC0603FR-07210KL-Yageo-datasheet-137700087.pdf?src-supplier=Future+Electronics; ComponentLink6URL: https://datasheet.octopart.com:443/RC0603FR-07210KL-Yageo-datasheet-137700087.pdf?src-supplier=Future+Electronics; ComponentLink7Description: https://datasheet.octopart.com:443/RC0603FR-07210KL-Yageo-datasheet-15599030.pdf?src-supplier=Future+Electronics; ComponentLink7URL: https://datasheet.octopart.com:443/RC0603FR-07210KL-Yageo-datasheet-15599030.pdf?src-supplier=Future+Electronics; ComponentLink8Description: https://datasheet.octopart.com:443/RC0603FR-07210KL-Yageo-datasheet-12596169.pdf?src-supplier=Future+Electronics; ComponentLink8URL: https://datasheet.octopart.com:443/RC0603FR-07210KL-Yageo-datasheet-12596169.pdf?src-supplier=Future+Electronics; ComponentLink9Description: https://datasheet.octopart.com:443/RC0603FR-07210KL-Yageo-datasheet-8328172.pdf?src-supplier=Future+Electronics; ComponentLink9URL: https://datasheet.octopart.com:443/RC0603FR-07210KL-Yageo-datasheet-8328172.pdf?src-supplier=Future+Electronics; ComponentLink10Description: https://datasheet.octopart.com:443/RC0603FR-07210KL-Yageo-datasheet-79472498.pdf?src-supplier=Megastar; ComponentLink10URL: https://datasheet.octopart.com:443/RC0603FR-07210KL-Yageo-datasheet-79472498.pdf?src-supplier=Megastar; ComponentLink11Description: https://datasheet.octopart.com/RC0603FR-07210KL-Yageo-datasheet-73766026.pdf; ComponentLink11URL: https://datasheet.octopart.com/RC0603FR-07210KL-Yageo-datasheet-73766026.pdf; ComponentLink12Description: https://datasheet.octopart.com:443/RC0603FR-07210KL-Yageo-datasheet-118562.pdf?src-supplier=DigiKey; ComponentLink12URL: https://datasheet.octopart.com:443/RC0603FR-07210KL-Yageo-datasheet-118562.pdf?src-supplier=DigiKey; ComponentLink13Description: http://sigma.octopart.com:80/conflict_mineral_statement/Yageo-company-3.pdf?src-supplier=Compliance+Statements; ComponentLink13URL: http://sigma.octopart.com:80/conflict_mineral_statement/Yageo-company-3.pdf?src-supplier=Compliance+Statements; ComponentLink14Description: http://sigma.octopart.com:80/rohs_statement/Yageo-company-2.pdf?src-supplier=Compliance+Statements; ComponentLink14URL: http://sigma.octopart.com:80/rohs_statement/Yageo-company-2.pdf?src-supplier=Compliance+Statements; Composition: Thick Film; Depth: 800µm; Features: Moisture Resistant; Height: 450µm; Lead Free: Lead Free; Length: 1.6mm; Library Name: Passives.SchLib; Lifecycle Status: Production; Max Operating Temperature: 155°C; Max Power Dissipation: 100mW; Min Operating Temperature: -55°C; Mounting Technology: Surface Mount; Number of Terminals: 2; Number of Terminations: 2; Packaging: Tape & Reel; Power Rating: 100mW; Pricing: 1=0.103 (USD); REACH SVHC: Yes; RoHS: Compliant; Schedule B: 8533210045, 8533210045|8533210045|8533210045|8533210045|8533210045; Stock: 2692; Supplier 1: Avnet; Supplier Part Number 1: 49AK1945; Termination: SMD/SMT; Tolerance: 1%; Value: 210k; Voltage Rating: 75V; Width: 800µm; Working Voltage: 75V
R65 = RES: Res Thick Film 0603 470 Ohm 1% 0.1W(1/10W) ±100ppm/C Pad SMD T/R; Manufacturer: Yageo Group; Manufacturer Part Number: RC0603FR-07470RL; Impedance: 50Ω; Max Power Dissipation: 100mW; Temperature Coefficient: 100ppm/°C; Voltage Rating: 75V; Voltage Rating (DC): 50V; Case Code (Imperial): 0603; Case Code (Metric): 0603; Case/Package: 0603; Category: Chip SMD Resistors; China RoHS: Non-Compliant; Component Kind: Standard; ComponentLink1Description: https://datasheet.octopart.com:443/RC0603FR-07470RL-Yageo-datasheet-175887523.pdf?src-supplier=Newark; ComponentLink1URL: https://datasheet.octopart.com:443/RC0603FR-07470RL-Yageo-datasheet-175887523.pdf?src-supplier=Newark; ComponentLink2Description: https://datasheet.octopart.com:443/RC0603FR-07470RL-Yageo-datasheet-8328172.pdf?src-supplier=Newark; ComponentLink2URL: https://datasheet.octopart.com:443/RC0603FR-07470RL-Yageo-datasheet-8328172.pdf?src-supplier=Newark; ComponentLink3Description: https://datasheet.octopart.com:443/RC0603FR-07470RL-Yageo-Group-datasheet-190051977.pdf?src-supplier=Newark; ComponentLink3URL: https://datasheet.octopart.com:443/RC0603FR-07470RL-Yageo-Group-datasheet-190051977.pdf?src-supplier=Newark; ComponentLink4Description: https://datasheet.octopart.com:443/RC0603FR-07470RL-Yageo-datasheet-12596169.pdf?src-supplier=Newark; ComponentLink4URL: https://datasheet.octopart.com:443/RC0603FR-07470RL-Yageo-datasheet-12596169.pdf?src-supplier=Newark; ComponentLink5Description: https://datasheet.octopart.com:443/RC0603FR-07470RL-Yageo-datasheet-103092701.pdf?src-supplier=Upverter; ComponentLink5URL: https://datasheet.octopart.com:443/RC0603FR-07470RL-Yageo-datasheet-103092701.pdf?src-supplier=Upverter; ComponentLink6Description: https://datasheet.octopart.com:443/RC0603FR-07470RL-Yageo-datasheet-98239111.pdf?src-supplier=Upverter; ComponentLink6URL: https://datasheet.octopart.com:443/RC0603FR-07470RL-Yageo-datasheet-98239111.pdf?src-supplier=Upverter; ComponentLink7Description: https://datasheet.octopart.com:443/RC0603FR-07470RL-Yageo-datasheet-34211833.pdf?src-supplier=element14+APAC; ComponentLink7URL: https://datasheet.octopart.com:443/RC0603FR-07470RL-Yageo-datasheet-34211833.pdf?src-supplier=element14+APAC; ComponentLink8Description: https://datasheet.octopart.com:443/RC0603FR-07470RL-Yageo-datasheet-17842380.pdf?src-supplier=element14+APAC; ComponentLink8URL: https://datasheet.octopart.com:443/RC0603FR-07470RL-Yageo-datasheet-17842380.pdf?src-supplier=element14+APAC; ComponentLink9Description: https://datasheet.octopart.com:443/RC0603FR-07470RL-Yageo-datasheet-62067350.pdf?src-supplier=Farnell; ComponentLink9URL: https://datasheet.octopart.com:443/RC0603FR-07470RL-Yageo-datasheet-62067350.pdf?src-supplier=Farnell; ComponentLink10Description: https://datasheet.octopart.com:443/RC0603FR-07470RL-Yageo-datasheet-13691069.pdf?src-supplier=Farnell; ComponentLink10URL: https://datasheet.octopart.com:443/RC0603FR-07470RL-Yageo-datasheet-13691069.pdf?src-supplier=Farnell; ComponentLink11Description: https://datasheet.octopart.com:443/RC0603FR-07470RL-Yageo-Group-datasheet-176638170.pdf?src-supplier=Future+Electronics; ComponentLink11URL: https://datasheet.octopart.com:443/RC0603FR-07470RL-Yageo-Group-datasheet-176638170.pdf?src-supplier=Future+Electronics; ComponentLink12Description: https://datasheet.octopart.com:443/RC0603FR-07470RL-Yageo-datasheet-164759695.pdf?src-supplier=Future+Electronics; ComponentLink12URL: https://datasheet.octopart.com:443/RC0603FR-07470RL-Yageo-datasheet-164759695.pdf?src-supplier=Future+Electronics; ComponentLink13Description: https://datasheet.octopart.com:443/RC0603FR-07470RL-Yageo-datasheet-137700087.pdf?src-supplier=Future+Electronics; ComponentLink13URL: https://datasheet.octopart.com:443/RC0603FR-07470RL-Yageo-datasheet-137700087.pdf?src-supplier=Future+Electronics; ComponentLink14Description: https://datasheet.octopart.com:443/RC0603FR-07470RL-Yageo-datasheet-127823281.pdf?src-supplier=Future+Electronics; ComponentLink14URL: https://datasheet.octopart.com:443/RC0603FR-07470RL-Yageo-datasheet-127823281.pdf?src-supplier=Future+Electronics; ComponentLink15Description: https://datasheet.octopart.com:443/RC0603FR-07470RL-Yageo-datasheet-27584510.pdf?src-supplier=Future+Electronics; ComponentLink15URL: https://datasheet.octopart.com:443/RC0603FR-07470RL-Yageo-datasheet-27584510.pdf?src-supplier=Future+Electronics; ComponentLink16Description: https://datasheet.octopart.com:443/RC0603FR-07470RL-Yageo-datasheet-15599030.pdf?src-supplier=Future+Electronics; ComponentLink16URL: https://datasheet.octopart.com:443/RC0603FR-07470RL-Yageo-datasheet-15599030.pdf?src-supplier=Future+Electronics; ComponentLink17Description: https://datasheet.octopart.com:443/RC0603FR-07470RL-Yageo-datasheet-503470.pdf?src-supplier=Future+Electronics; ComponentLink17URL: https://datasheet.octopart.com:443/RC0603FR-07470RL-Yageo-datasheet-503470.pdf?src-supplier=Future+Electronics; ComponentLink18Description: https://datasheet.octopart.com:443/RC0603FR-07470RL-Yageo-datasheet-79472498.pdf?src-supplier=Megastar; ComponentLink18URL: https://datasheet.octopart.com:443/RC0603FR-07470RL-Yageo-datasheet-79472498.pdf?src-supplier=Megastar; ComponentLink19Description: https://datasheet.octopart.com/RC0603FR-07470RL-Yageo-datasheet-73766026.pdf; ComponentLink19URL: https://datasheet.octopart.com/RC0603FR-07470RL-Yageo-datasheet-73766026.pdf; ComponentLink20Description: https://datasheet.octopart.com:443/RC0603FR-07470RL-Yageo-datasheet-118562.pdf?src-supplier=DigiKey; ComponentLink20URL: https://datasheet.octopart.com:443/RC0603FR-07470RL-Yageo-datasheet-118562.pdf?src-supplier=DigiKey; ComponentLink21Description: http://sigma.octopart.com:80/conflict_mineral_statement/Yageo-company-3.pdf?src-supplier=Compliance+Statements; ComponentLink21URL: http://sigma.octopart.com:80/conflict_mineral_statement/Yageo-company-3.pdf?src-supplier=Compliance+Statements; ComponentLink22Description: http://sigma.octopart.com:80/rohs_statement/Yageo-company-2.pdf?src-supplier=Compliance+Statements; ComponentLink22URL: http://sigma.octopart.com:80/rohs_statement/Yageo-company-2.pdf?src-supplier=Compliance+Statements; Composition: Thick Film; Contact Material: Brass; Contact Plating: Gold; Depth: 800µm; Features: Moisture Resistant; Height: 450µm; Lead Free: Lead Free; Length: 1.6mm; Library Name: Passives.SchLib; Lifecycle Status: Production; Max Operating Temperature: 155°C; Military Standard: Not; Min Operating Temperature: -55°C; Mounting Technology: Surface Mount; Number of Terminals: 2; Number of Terminations: 2; Packaging: Tape and Reel; Pins: 2; Power Rating: 100mW; Pricing: 5000=0.00137, 10000=0.00137, 20000=0.00136, 40000=0.00135, 80000=0.00134 (USD); Radiation Hardening: No; REACH SVHC: Yes; RoHS: Compliant; Schedule B: 8533210045, 8533210045|8533210045, 8533210045|8533210045|8533210045, 8533210045|8533210045|8533210045|8533210045; Stock: 510000; Supplier 1: Avnet; Supplier Part Number 1: RC0603FR-07470RL; Termination: SMD/SMT; Tolerance: 1%; Value: 470R; Width: 800µm; Working Voltage: 75V
SW3 = SW_SPDT: Switch Slide ON ON SPDT Side Slide 0.1A 12VDC 10000Cycle PC Pins Thru-Hole Bulk; Manufacturer: C&K Components; Manufacturer Part Number: OS102011MA1QN1; Actuator Length: 3.9878mm; Actuator Material: Polyoxymethylene; Body Material: Tin; Contact Current Rating: 100mA; Contact Resistance: 20mΩ; Contact Style: Non-Shorting; Dielectric Material: Phenolic; Electrical Life: 10kcycles; Insulation Resistance: 100MΩ; Max Voltage Rating (DC): 12V; Number of Positions: 2; Switch Function: On-On; Switch Travel: 2mm; Category: Slide Switches; Color: Black; Component Kind: Standard; ComponentLink1Description: https://datasheet.octopart.com:443/OS102011MA1QN1-C%26K-Components-datasheet-165712316.pdf?src-supplier=IHS; ComponentLink1URL: https://datasheet.octopart.com:443/OS102011MA1QN1-C%26K-Components-datasheet-165712316.pdf?src-supplier=IHS; ComponentLink2Description: https://datasheet.octopart.com:443/OS102011MA1QN1-C%26K-Components-datasheet-9645547.pdf?src-supplier=IHS; ComponentLink2URL: https://datasheet.octopart.com:443/OS102011MA1QN1-C%26K-Components-datasheet-9645547.pdf?src-supplier=IHS; ComponentLink3Description: https://datasheet.octopart.com:443/OS102011MA1QN1-C%26K-Components-datasheet-100062965.pdf?src-supplier=Upverter; ComponentLink3URL: https://datasheet.octopart.com:443/OS102011MA1QN1-C%26K-Components-datasheet-100062965.pdf?src-supplier=Upverter; ComponentLink4Description: https://datasheet.octopart.com:443/OS102011MA1QN1-C%26K-Components-datasheet-67873706.pdf?src-supplier=Upverter; ComponentLink4URL: https://datasheet.octopart.com:443/OS102011MA1QN1-C%26K-Components-datasheet-67873706.pdf?src-supplier=Upverter; ComponentLink5Description: https://datasheet.octopart.com:443/OS102011MA1QN1-C%26K-Components-datasheet-77351947.pdf?src-supplier=Electro+Sonic; ComponentLink5URL: https://datasheet.octopart.com:443/OS102011MA1QN1-C%26K-Components-datasheet-77351947.pdf?src-supplier=Electro+Sonic; ComponentLink6Description: https://datasheet.octopart.com:443/OS102011MA1QN1-C%26K-Components-datasheet-142755260.pdf?src-supplier=Electro+Sonic; ComponentLink6URL: https://datasheet.octopart.com:443/OS102011MA1QN1-C%26K-Components-datasheet-142755260.pdf?src-supplier=Electro+Sonic; ComponentLink7Description: https://datasheet.octopart.com:443/OS102011MA1QN1-C%26K-Components-datasheet-44395687.pdf?src-supplier=Electro+Sonic; ComponentLink7URL: https://datasheet.octopart.com:443/OS102011MA1QN1-C%26K-Components-datasheet-44395687.pdf?src-supplier=Electro+Sonic; ComponentLink8Description: https://datasheet.octopart.com:443/OS102011MA1QN1-C%26K-Components-datasheet-14434867.pdf?src-supplier=Electro+Sonic; ComponentLink8URL: https://datasheet.octopart.com:443/OS102011MA1QN1-C%26K-Components-datasheet-14434867.pdf?src-supplier=Electro+Sonic; ComponentLink9Description: https://datasheet.octopart.com:443/OS102011MA1QN1-C%26K-Components-datasheet-13440078.pdf?src-supplier=element14+APAC; ComponentLink9URL: https://datasheet.octopart.com:443/OS102011MA1QN1-C%26K-Components-datasheet-13440078.pdf?src-supplier=element14+APAC; ComponentLink10Description: https://datasheet.octopart.com:443/OS102011MA1QN1-C%26K-Components-datasheet-150821956.pdf?src-supplier=Burklin+Elektronik; ComponentLink10URL: https://datasheet.octopart.com:443/OS102011MA1QN1-C%26K-Components-datasheet-150821956.pdf?src-supplier=Burklin+Elektronik; ComponentLink11Description: https://datasheet.octopart.com:443/OS102011MA1QN1-C%26K-Components-datasheet-24578929.pdf?src-supplier=Future+Electronics; ComponentLink11URL: https://datasheet.octopart.com:443/OS102011MA1QN1-C%26K-Components-datasheet-24578929.pdf?src-supplier=Future+Electronics; ComponentLink12Description: https://datasheet.octopart.com:443/OS102011MA1QN1-C%26K-Components-datasheet-62111170.pdf?src-supplier=Future+Electronics; ComponentLink12URL: https://datasheet.octopart.com:443/OS102011MA1QN1-C%26K-Components-datasheet-62111170.pdf?src-supplier=Future+Electronics; ComponentLink13Description: https://datasheet.octopart.com:443/OS102011MA1QN1-C%26K-Components-datasheet-7283719.pdf?src-supplier=Jameco; ComponentLink13URL: https://datasheet.octopart.com:443/OS102011MA1QN1-C%26K-Components-datasheet-7283719.pdf?src-supplier=Jameco; ComponentLink14Description: https://datasheet.octopart.com:443/OS102011MA1QN1-C%26K-Components-datasheet-62112609.pdf?src-supplier=RS+(Formerly+Allied+Electronics); ComponentLink14URL: https://datasheet.octopart.com:443/OS102011MA1QN1-C%26K-Components-datasheet-62112609.pdf?src-supplier=RS+(Formerly+Allied+Electronics); ComponentLink15Description: https://datasheet.octopart.com:443/OS102011MA1QN1-ITT-datasheet-542779.pdf?src-supplier=Farnell; ComponentLink15URL: https://datasheet.octopart.com:443/OS102011MA1QN1-ITT-datasheet-542779.pdf?src-supplier=Farnell; ComponentLink16Description: http://sigma.octopart.com:80/reach_statement/ITT-company-18.pdf?src-supplier=Compliance+Statements; ComponentLink16URL: http://sigma.octopart.com:80/reach_statement/ITT-company-18.pdf?src-supplier=Compliance+Statements; Contact Material: Brass; Contact Plating: Silver; Current Rating: 100mA; Depth: 8.4mm; Export Control Classification Number (ECCN) Code: EAR99; Harmonized Tariff Schedule (HTS) Code: 8536.50.90.50; Height: 4.7mm; Housing Material: Resin; Introduction Date: 2006-02-08; Lead Free: Lead Free; Length: 8.6mm; Library Name: Standard.SchLib; Lifecycle Status: Production; Max Current Rating: 100mA; Max Operating Temperature: 70°C; Mechanical Life: 10kcycles; Min Operating Temperature: -10°C; Mounting Technology: Brackets, PCB, Through Hole; Orientation: Right Angle; Packaging: Bulk; Pricing: 1=0.3589, 449=0.3179, 1124=0.3134, 2247=0.2967, 4494=0.2871, 8989=0.2781 (USD); Radiation Hardening: No; REACH SVHC: Yes; RoHS: Compliant; Stock: 944; Supplier 1: Sager Electronics; Supplier Part Number 1: OS102011MA1QN1; Terminal Material: Brass; Termination: PC Pins; Throw Configuration: SPDT; Voltage Rating (DC): 12V; Width: 4.4mm
TP9-TP15 = TP: Library Name: Passives.SchLib
U6 = XTPS55285VALR: 22V 8A buck-boost converter with I²C interface 15-WQFN-HR -40 to 125; Manufacturer: Texas Instruments; Manufacturer Lifecycle Status: ACTIVE; Category: Voltage Regulators - Switching; Component Kind: Standard; ComponentLink1Description: https://octopart.com:443/opatz8j6/c1?t=tixoSV1gCGqczMocm3I9OKrk8eaKkccHY9g_1ElVG9yucqZVouug_j8Q9OOTnJLvTijulg3rDwt1smdoN-zh07xMNjk_dhfSBkBT8Jkwjzp99TLWFXbbaK4hImVgzE0XFyBXxEvC-qoiY2OTt51xYy0xUbrWQOhaLO_eAozdfx7Rfu2m9J9MhF-17Y7dtTN3NXzLvJgQTEJUUtvNMDRG0FJZCJ29JLnkhbGy2axpQyizEj9FnGfVuPDqxeDNt-U0C-z41GSpYP9T8hdz_-kB1n1XgheAs6UpqiBEm9HzSwg2Lkv_dz2k3xcFx34LUQ3M2UELK1K55e4UrnCF5adijfSlu4bPcfDbhj7FzKH5uV6DMkG0dAiNO6wWqkfATbu_bIVVHTIWfw&src-supplier=Texas+Instruments; ComponentLink1URL: https://octopart.com:443/opatz8j6/c1?t=tixoSV1gCGqczMocm3I9OKrk8eaKkccHY9g_1ElVG9yucqZVouug_j8Q9OOTnJLvTijulg3rDwt1smdoN-zh07xMNjk_dhfSBkBT8Jkwjzp99TLWFXbbaK4hImVgzE0XFyBXxEvC-qoiY2OTt51xYy0xUbrWQOhaLO_eAozdfx7Rfu2m9J9MhF-17Y7dtTN3NXzLvJgQTEJUUtvNMDRG0FJZCJ29JLnkhbGy2axpQyizEj9FnGfVuPDqxeDNt-U0C-z41GSpYP9T8hdz_-kB1n1XgheAs6UpqiBEm9HzSwg2Lkv_dz2k3xcFx34LUQ3M2UELK1K55e4UrnCF5adijfSlu4bPcfDbhj7FzKH5uV6DMkG0dAiNO6wWqkfATbu_bIVVHTIWfw&src-supplier=Texas+Instruments; ComponentLink2Description: http://sigma.octopart.com:80/reach_statement/Texas-Instruments-company-64.pdf?src-supplier=Compliance+Statements; ComponentLink2URL: http://sigma.octopart.com:80/reach_statement/Texas-Instruments-company-64.pdf?src-supplier=Compliance+Statements; ComponentLink3Description: http://sigma.octopart.com:80/conflict_mineral_statement/Texas-Instruments-company-63.pdf?src-supplier=Compliance+Statements; ComponentLink3URL: http://sigma.octopart.com:80/conflict_mineral_statement/Texas-Instruments-company-63.pdf?src-supplier=Compliance+Statements; Datasheet: https://www.ti.com/lit/gpn/tps55285; Height: 0m; Length: 2.5mm; Library Name: Power.SchLib; Lifecycle Status: Production; Manufacturer Part Number: TPS55285VALR; Manufacturer_Name: Texas Instruments; Manufacturer_Part_Number: XTPS55285VALR; Pins: 15; Pricing: 1=4.03, 10=3.047, 25=2.8016, 100=2.5314, 250=2.4026, 500=2.32496, 1000=2.26108 (USD); RefDes: RefDes; RoHS: Compliant; Stock: 1651; Supplier 1: DigiKey; Supplier Part Number 1: 296-TPS55285VALRCT-ND; Thickness: 700µm; Topology: Buck-Boost; TYPE: TYPE; Width: 3.5mm; Copyright: Copyright (C) 2026 Ultra Librarian. All rights reserved.
